# Altium schematic sheet Flash.SchDoc(Flash)
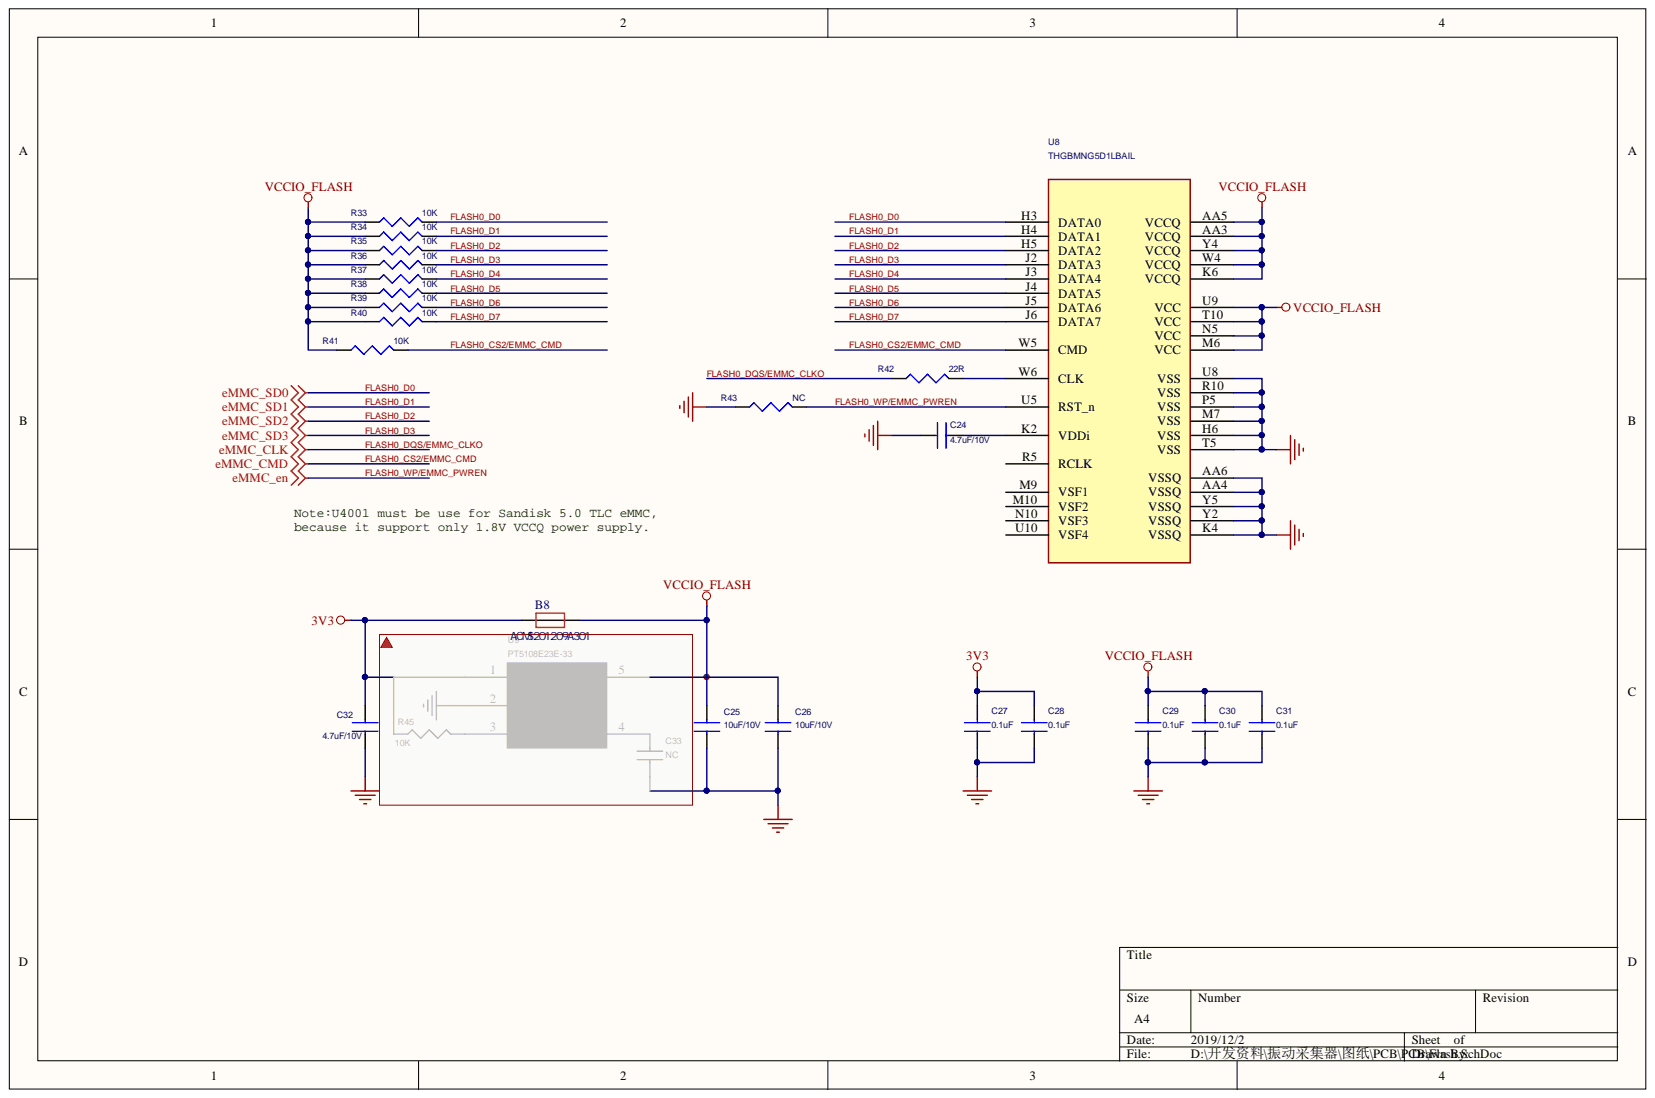
[diagram p0: Flash.SchDoc]

## Parts
B8  ACMS201209A301  [0805_R]
C24  4.7uF/10V  [0402_C]
C25  10uF/10V  [0603_C]
C26  10uF/10V  [0603_C]
C27  0.1uF  [0402_C]
C28  0.1uF  [0402_C]
C29  0.1uF  [0402_C]
C30  0.1uF  [0402_C]
C31  0.1uF  [0402_C]
C32  4.7uF/10V  [0402_C]
R33  10K  [0402_R]
R34  10K  [0402_R]
R35  10K  [0402_R]
R36  10K  [0402_R]
R37  10K  [0402_R]
R38  10K  [0402_R]
R39  10K  [0402_R]
R40  10K  [0402_R]
R41  10K  [0402_R]
R42  22R  [0402_R]
R43  NC  [0402_R]
U8  THGBMNG5D1LBAIL  [INAND_169_A]

## Nets
3V3: B8.1 C27.2 C28.2 C32.1
GND: C24.1 C25.2 C26.2 C27.1 C28.1 C29.1 C30.1 C31.1 C32.2 R43.1 U8.AA4(VSSQ) U8.AA6(VSSQ) U8.H6(VSS) U8.K4(VSSQ) U8.M7(VSS) U8.P5(VSS) U8.R10(VSS) U8.T5(VSS) U8.U8(VSS) U8.Y2(VSSQ) U8.Y5(VSSQ)
VCCIO_FLASH: B8.2 C25.1 C26.1 C29.2 C30.2 C31.2 R33.1 R34.1 R35.1 R36.1 R37.1 R38.1 R39.1 R40.1 R41.1 U8.AA3(VCCQ) U8.AA5(VCCQ) U8.K6(VCCQ) U8.M6(VCC) U8.N5(VCC) U8.T10(VCC) U8.U9(VCC) U8.W4(VCCQ) U8.Y4(VCCQ)
FLASH0_CS2/EMMC_CMD: R41.2 U8.W5(CMD)
FLASH0_D0: R33.2 U8.H3(DATA0)
FLASH0_D1: R34.2 U8.H4(DATA1)
FLASH0_D2: R35.2 U8.H5(DATA2)
FLASH0_D3: R36.2 U8.J2(DATA3)
FLASH0_D4: R37.2 U8.J3(DATA4)
FLASH0_D5: R38.2 U8.J4(DATA5)
FLASH0_D6: R39.2 U8.J5(DATA6)
FLASH0_D7: R40.2 U8.J6(DATA7)
FLASH0_DQS/EMMC_CLKO: R42.1
FLASH0_WP/EMMC_PWREN: R43.2 U8.U5(RST_n)
NetC24_2: C24.2 U8.K2(VDDi)
NetR42_2: R42.2 U8.W6(CLK)

Not connected: U8 M9(VSF1) M10(VSF2) N10(VSF3) R5(RCLK) U10(VSF4)

## Library data of the parts
B8 = Bead: Component Kind: Standard; Library Name: RP3288BV03_20180126-.SCHLIB
C24 C27-C31 = CAP NP_Dup4: RoHS CHIP CAP 0.1uF +10/-10% X7R; Component Kind: Standard
C25 C26 C32 = CAP NP_Dup4: RoHS CHIP CAP 4.7uF +10/-10% X5R; Component Kind: Standard
R33-R40 R42 = RESISTOR_Dup2: NC; Component Kind: Standard
R41 = RESISTOR_Dup2: ROHS CHIP RES 10K 1/16W +/-5%; Component Kind: Standard
R43 = RESISTOR_Dup2: Component Kind: Standard; Description: NC
U8 = INAND_1: NAND Flash,H26M54001BKR,2.7V,3.3V,3.6V,128G,N/A,-25℃~85℃,FBGA-169P; Component Kind: Standard
